# Altium schematic sheet W708_ref.SchDoc
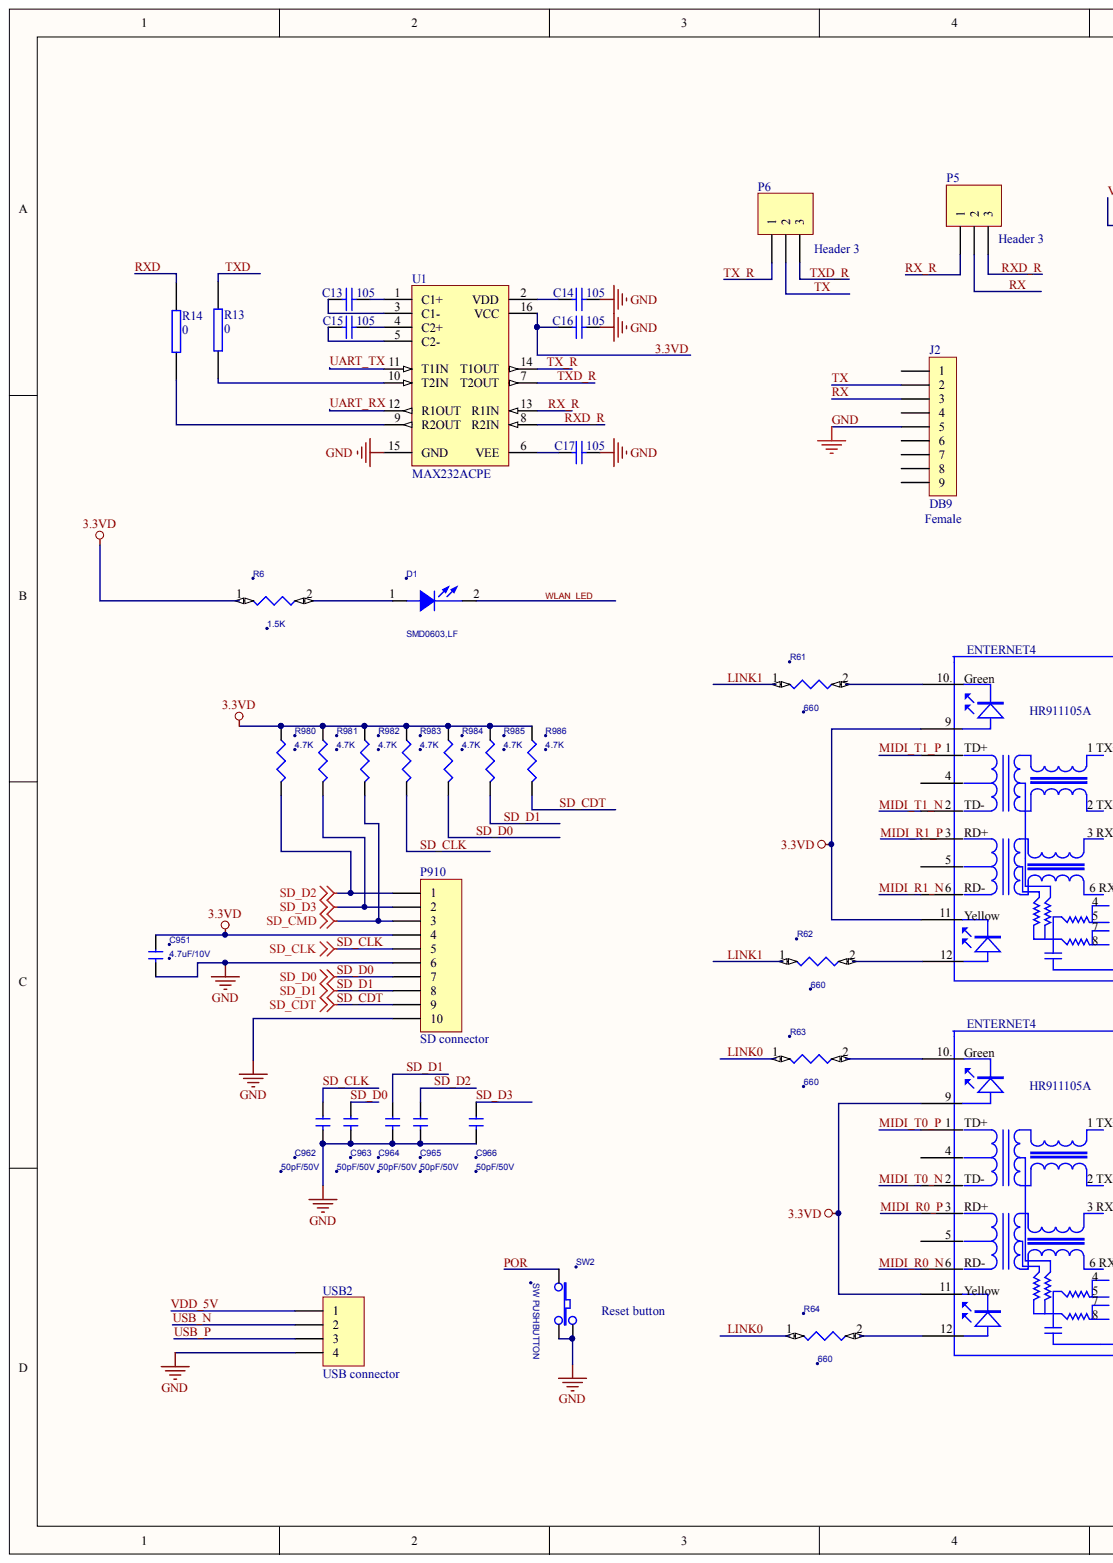
[diagram p0: W708_ref.SchDoc, left]
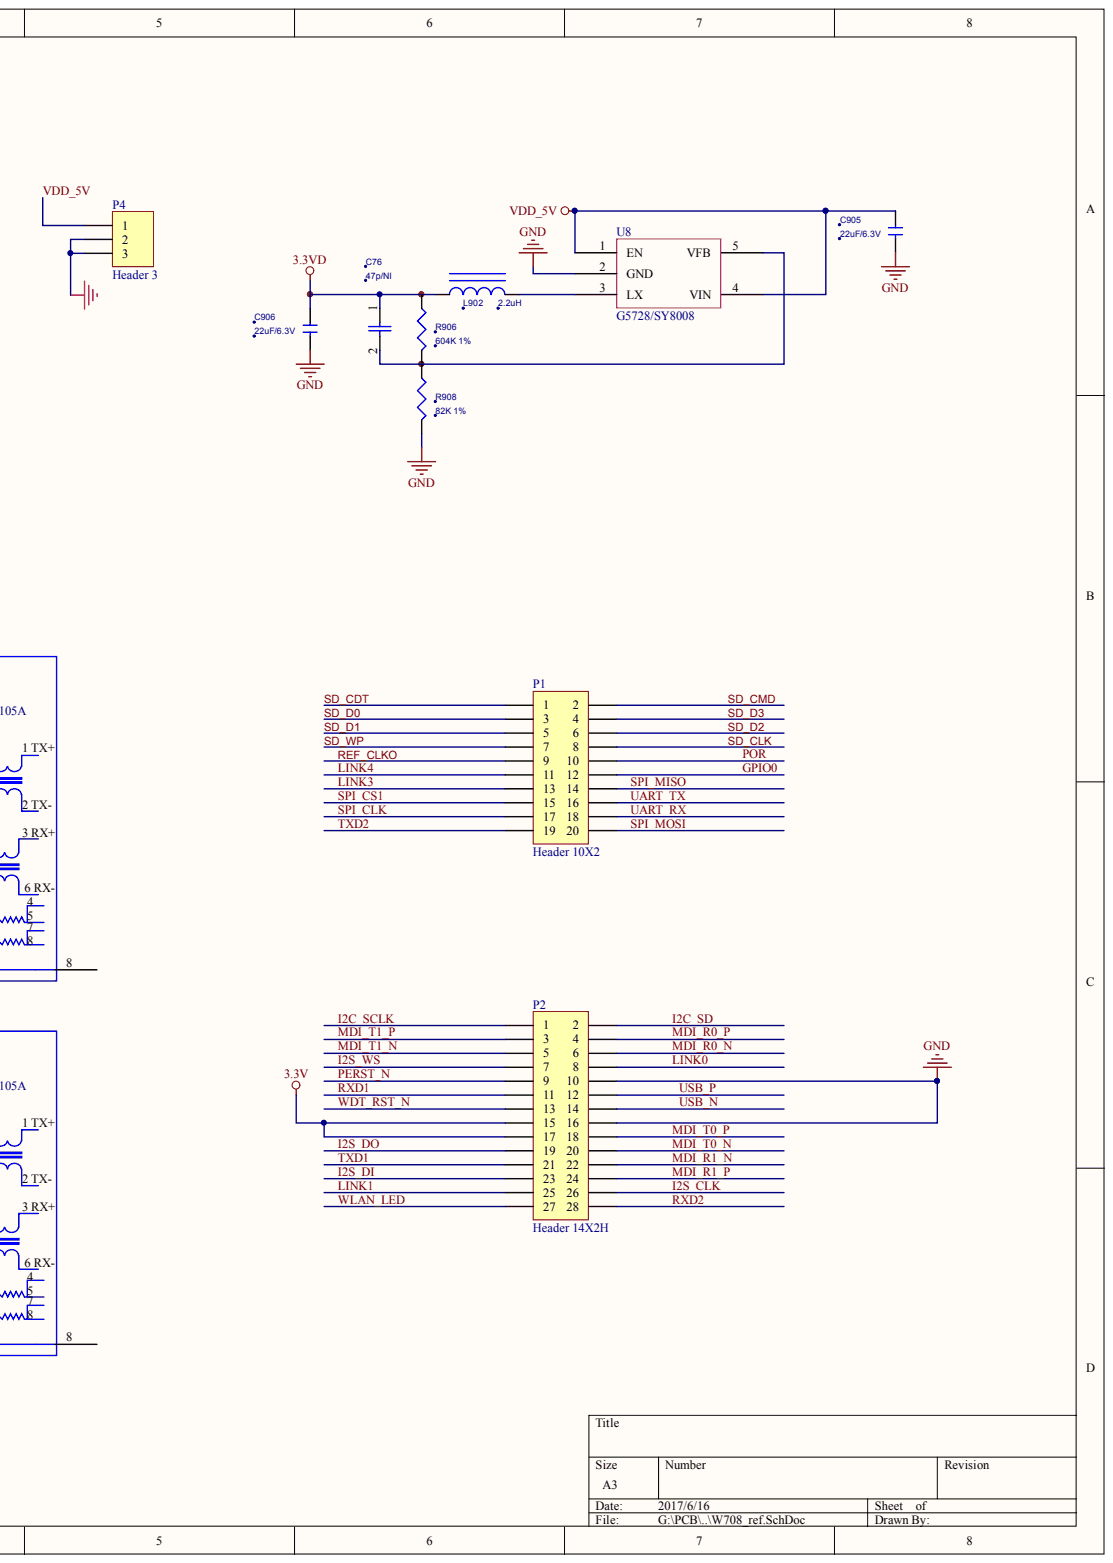
[diagram p1: W708_ref.SchDoc, right]

## Parts
C13  105  [0603]
C14  105  [0603]
C15  105  [0603]
C16  105  [0603]
C17  105  [0603]
C76  47p/NI  [C0402]
C905  22uF/6.3V  [C0805]
C906  22uF/6.3V  [C0805]
C951  4.7uF/10V  [C0603]
C962  50pF/50V  [C0402]
C963  50pF/50V  [C0402]
C964  50pF/50V  [C0402]
C965  50pF/50V  [C0402]
C966  50pF/50V  [C0402]
D1  SMD0603,LF  [0603]
ENTERNET4  HR911105A  [HR911105A]
ENTERNET4  HR911105A  [HR911105A]
J2  DB9  [DB9S]
L902  2.2uH  [l2512]
P1  Header 10X2  [1.27_20]
P2  Header 14X2H  [1.27_28]
P4  Header 3  [POWER_STK1]
P5  Header 3  [HDR1X3]
P6  Header 3  [HDR1X3]
P910  SD connector  [uSD_KT76009]
R6  1.5K  [0402]
R13  0  [0603]
R14  0  [0603]
R61  660  [0402]
R62  660  [0402]
R63  660  [0402]
R64  660  [0402]
R906  604K 1%  [R0402]
R908  82K 1%  [R0402]
R980  4.7K  [R0402]
R981  4.7K  [R0402]
R982  4.7K  [R0402]
R983  4.7K  [R0402]
R984  4.7K  [R0402]
R985  4.7K  [R0402]
R986  4.7K  [R0402]
SW2  SW PUSHBUTTON  [INT_TACT]
U1  MAX232ACPE  [SOP-16]
U8  G5728/SY8008  [SOT23-5]
USB2  USB connector  [USB_A]

## Nets
3.3V: P2.15 P2.17
3.3VD: C16.2 C76.1 C906.1 C951.1 ENTERNET4.9 ENTERNET4.11 L902.1 P910.4 R6.1 R906.1 R980.1 R981.1 R982.1 R983.1 R984.1 R985.1 R986.1 U1.16(VCC)
GND: C14.1 C16.1 C17.1 C905.2 C906.2 C951.2 C962.2 C963.2 C964.2 C965.2 C966.2 J2.5 P2.10 P2.16 P4.2 P4.3 P910.6 P910.10 R908.2 SW2.1 SW2.3 U1.15(GND) U8.2(GND) USB2.4
GPIO0: P1.12
I2C_SCLK: P2.1
I2C_SD: P2.2
I2S_CLK: P2.26
I2S_DI: P2.23
I2S_DO: P2.19
I2S_WS: P2.7
LINK0: P2.8 R63.1 R64.1
LINK1: P2.25 R61.1 R62.1
LINK3: P1.13
LINK4: P1.11
MDI_R0_N: P2.6
MDI_R0_P: P2.4
MDI_R1_N: P2.22
MDI_R1_P: P2.24
MDI_T0_N: P2.20
MDI_T0_P: P2.18
MDI_T1_N: P2.5
MDI_T1_P: P2.3
MIDI_R0_N: ENTERNET4.6
MIDI_R0_P: ENTERNET4.3
MIDI_R1_N: ENTERNET4.6
MIDI_R1_P: ENTERNET4.3
MIDI_T0_N: ENTERNET4.2
MIDI_T0_P: ENTERNET4.1
MIDI_T1_N: ENTERNET4.2
MIDI_T1_P: ENTERNET4.1
PERST_N: P2.9
POR: P1.10 SW2.2
REF_CLKO: P1.9
RX: J2.3 P5.2
RX_R: P5.1 U1.13(R1IN)
RXD: R14.2
RXD1: P2.11
RXD2: P2.28
RXD_R: P5.3 U1.8(R2IN)
SD_CDT: P1.1 P910.9 R986.2
SD_CLK: C962.1 P1.8 P910.5 R983.2
SD_CMD: P1.2 P910.3 R982.2
SD_D0: C963.1 P1.3 P910.7 R984.2
SD_D1: C964.1 P1.5 P910.8 R985.2
SD_D2: C965.1 P1.6 P910.1 R980.2
SD_D3: C966.1 P1.4 P910.2 R981.2
SD_WP: P1.7
SPI_CLK: P1.17
SPI_CS1: P1.15
SPI_MISO: P1.14
SPI_MOSI: P1.20
TX: J2.2 P6.2
TX_R: P6.1 U1.14(T1OUT)
TXD: R13.2
TXD1: P2.21
TXD2: P1.19
TXD_R: P6.3 U1.7(T2OUT)
UART_RX: P1.18 U1.12(R1OUT)
UART_TX: P1.16 U1.11(T1IN)
USB_N: P2.14 USB2.2
USB_P: P2.12 USB2.3
VDD_5V: C905.1 P4.1 U8.1(EN) U8.4(VIN) USB2.1
WDT_RST_N: P2.13
WLAN_LED: D1.2 P2.27
NetC13_1: C13.1 U1.1(C1+)
NetC13_2: C13.2 U1.3(C1-)
NetC14_2: C14.2 U1.2(VDD)
NetC15_1: C15.1 U1.4(C2+)
NetC15_2: C15.2 U1.5(C2-)
NetC17_2: C17.2 U1.6(VEE)
NetC76_2: C76.2 R906.2 R908.1 U8.5(VFB)
NetD1_1: D1.1 R6.2
NetENTERNET4_10.: ENTERNET4.10. R61.2 R63.2
NetENTERNET4_12: ENTERNET4.12 R62.2 R64.2
NetL902_2: L902.2 U8.3(LX)
NetR13_1: R13.1 U1.10(T2IN)
NetR14_1: R14.1 U1.9(R2OUT)

Not connected: ENTERNET4 4 5 8; J2 1 4 6 7 8 9

## Library data of the parts
C13-C17 = Cap: Capacitor; Value: 100pF; Component Kind: Standard; LatestRevisionDate: 17-Jul-2002; LatestRevisionNote: Re-released for DXP Platform.; Library Name: Miscellaneous Devices.IntLib; PackageReference: RAD-0.3; Published: 8-Jun-2000; Publisher: Altium Limited
  C13-C16: Signal Integrity: Cap; Simulation: CAP
C76 = CAP/0402_1: Component Kind: Standard
C905 C906 = MLCC 22uF 6_1.3V 20% 0603 SMD: 1.料號: 101530226341; 2.品名: MLCC 22uF 6.3V M X5R 0805; 3.規格: C2012X5R0J226MT TDK; 4.型號: C2012X5R0J226MT; Component Kind: Standard
C951 = MLCC 4.7uF 10V 10% 0603 SMD: 1.料號: 101521475241; 2.品名: MLCC 4.7uF 10V K X5R 0603; 3.規格: C1608X5R1A475K TDK; 4.型號: C1608X5R1A475K; Component Kind: Standard
C962-C966 = MLCC 100pF 50V 5% 0402 SMD: 1.料號: 101514101111; 2.品名: MLCC 100pF 50V J NPO 0402; 3.規格: C1005C0G1H101JT TDK; 4.型號: C1005C0G1H101JT; Component Kind: Standard
D1 = LED/0603: Component Kind: Standard
J2 = CON9: Connector; Component Kind: Standard
L902 = IND2.2uH/1KHZ 1.8A 20% 3D18 SMD: 1.料號: 106120022101; 2.品名: IND PW 2.2uH/1KHZ M 1.8A 3D18; 3.規格: PIS3D18P-2R2 EMTEK; 4.型號: PIS3D18P-2R2; Component Kind: Standard
P1 = Header 10X2: Header, 10-Pin, Dual row; Component Kind: Standard; LatestRevisionDate: 17-Jul-2002; LatestRevisionNote: Re-released for DXP Platform.; Library Name: Miscellaneous Connectors.IntLib; Signal Integrity: Connector; Publisher: Altium Limited
P2 = Header 14X2H: Header, 14-Pin, Dual row, Right Angle; Component Kind: Standard; LatestRevisionDate: 17-Jul-2002; LatestRevisionNote: Re-released for DXP Platform.; Library Name: Miscellaneous Connectors.IntLib; Signal Integrity: Connector; Publisher: Altium Limited
P4-P6 = Header 3: Header, 3-Pin; Component Kind: Standard; LatestRevisionDate: 17-Jul-2002; LatestRevisionNote: Re-released for DXP Platform.; Library Name: Miscellaneous Connectors.IntLib; Signal Integrity: Connector; Publisher: Altium Limited
P910 = Header 10: Header, 10-Pin; Component Kind: Standard; LatestRevisionDate: 17-Jul-2002; LatestRevisionNote: Re-released for DXP Platform.; Library Name: Miscellaneous Connectors.IntLib; Signal Integrity: Connector; Publisher: Altium Limited
R6 R61-R64 = RES/0402_Dup2: Component Kind: Standard
R13 R14 = RES2: Component Kind: Standard
R906 = RES 220K 5% 1/16W 0402 SMD: Part number: 107152203500; Component Kind: Standard
R908 = RES 49K9 1% 1/16W 0603 SMD: Part number: 107144992100; Component Kind: Standard
R980-R986 = RES 1K 1% 1/16W 0402 SMD: Part number: 107151001100; Component Kind: Standard
SW2 = SW PUSHBUTTON-SPDT_0: 2.品規: TACT SW INT-1111 SMD 90'; 4.供應商: 訢寶; 5.供應商料號: INT-1111; Component Kind: Standard
U1 = MAX232ACPE: +5V Powered, Multi-Channel RS-232 Driver/Receiver; PackageDocument: 1997; Code_JEDEC: MS-001-BB; Component Kind: Standard; ComponentLink1Description: Manufacturer Link; ComponentLink1URL: http://www.maxim-ic.com/; ComponentLink2Description: Datasheet; ComponentLink2URL: http://pdfserv.maxim-ic.com/en/ds/MAX220-MAX249.pdf; LatestRevisionDate: 6-Jun-2005; LatestRevisionNote: Stylized 3D Model Added.; Library Name: Maxim Communication Transceiver.IntLib; PackageReference: PE16A; PCB3D: PE16; Published: 16-Jan-2001; Publisher: Altium Limited
U8 = G5728: Component Kind: Standard; Library Name: IC.SchLib
USB2 = Header 4: Header, 4-Pin; Component Kind: Standard; LatestRevisionDate: 17-Jul-2002; LatestRevisionNote: Re-released for DXP Platform.; Library Name: Miscellaneous Connectors.IntLib; Signal Integrity: Connector; Publisher: Altium Limited
ENTERNET4 ENTERNET4 = HR911105A: Component Kind: Standard
